# Altium schematic sheet CONNECTOR_IN.SchDoc(CONNECTOR_IN)
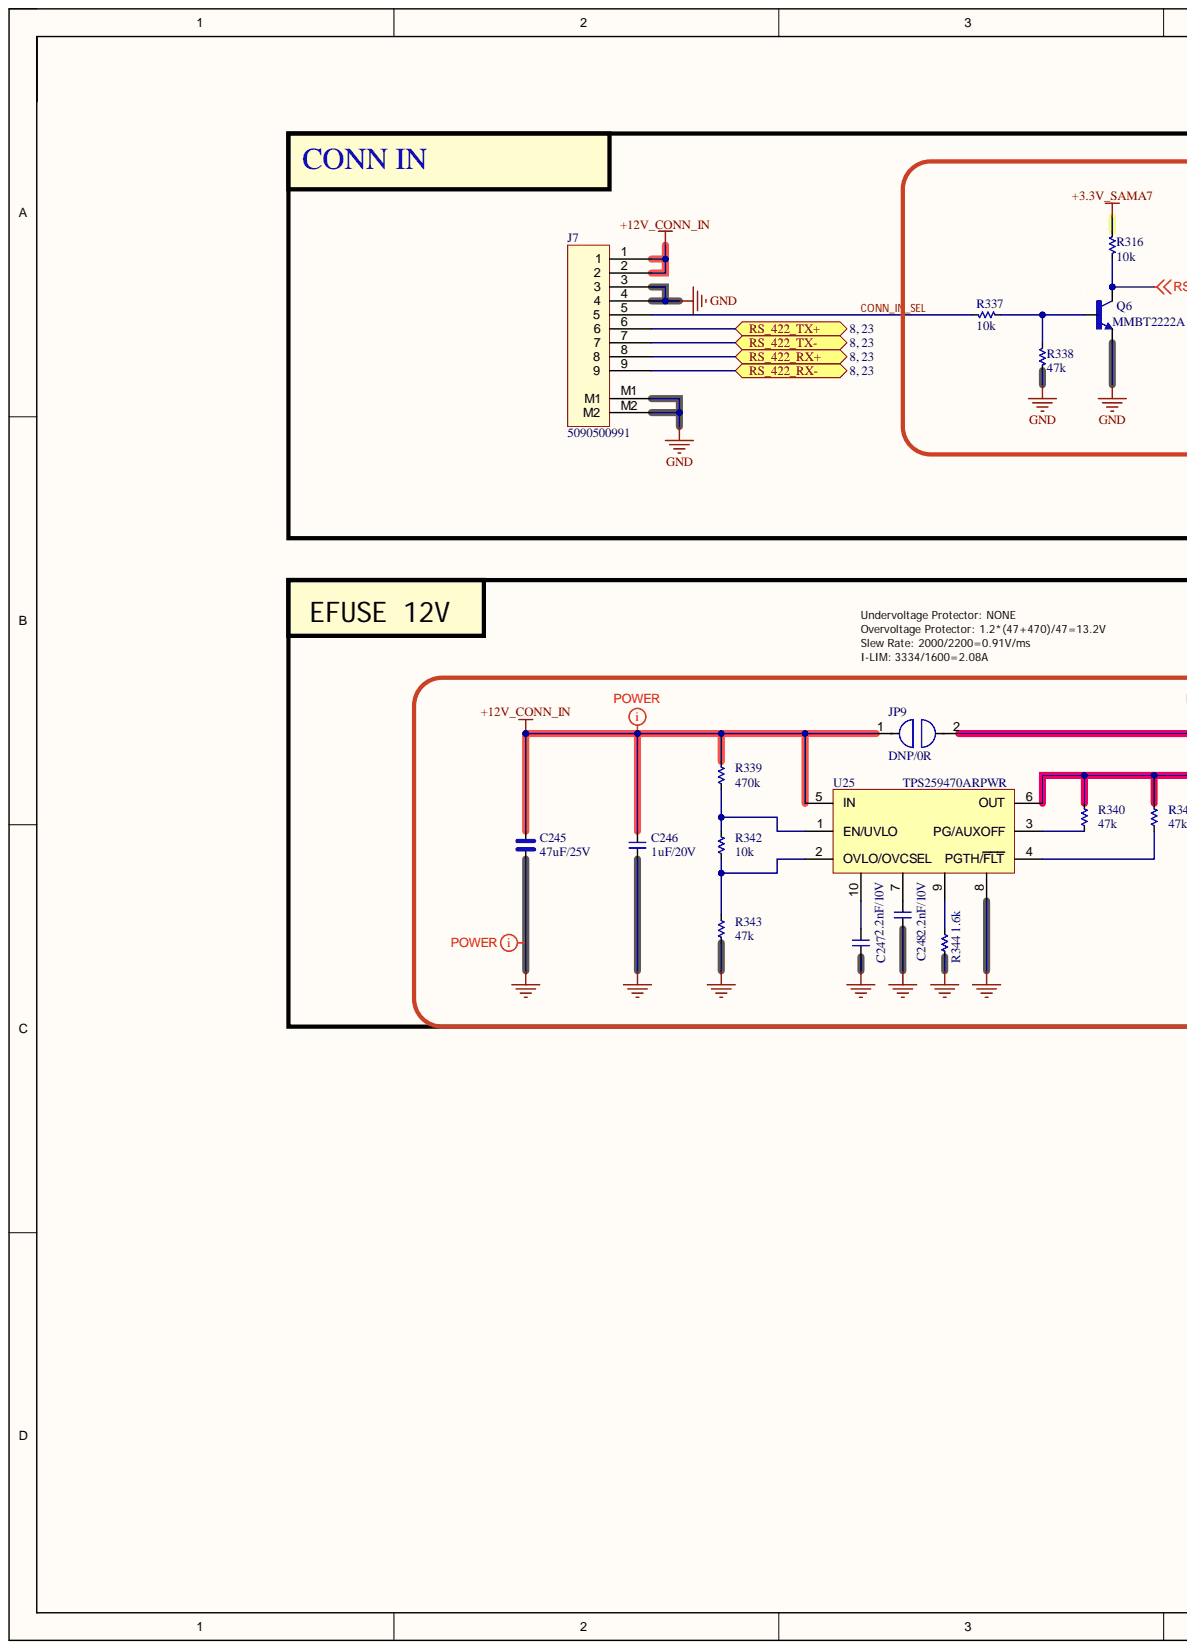
[diagram p0: CONNECTOR_IN.SchDoc, left]
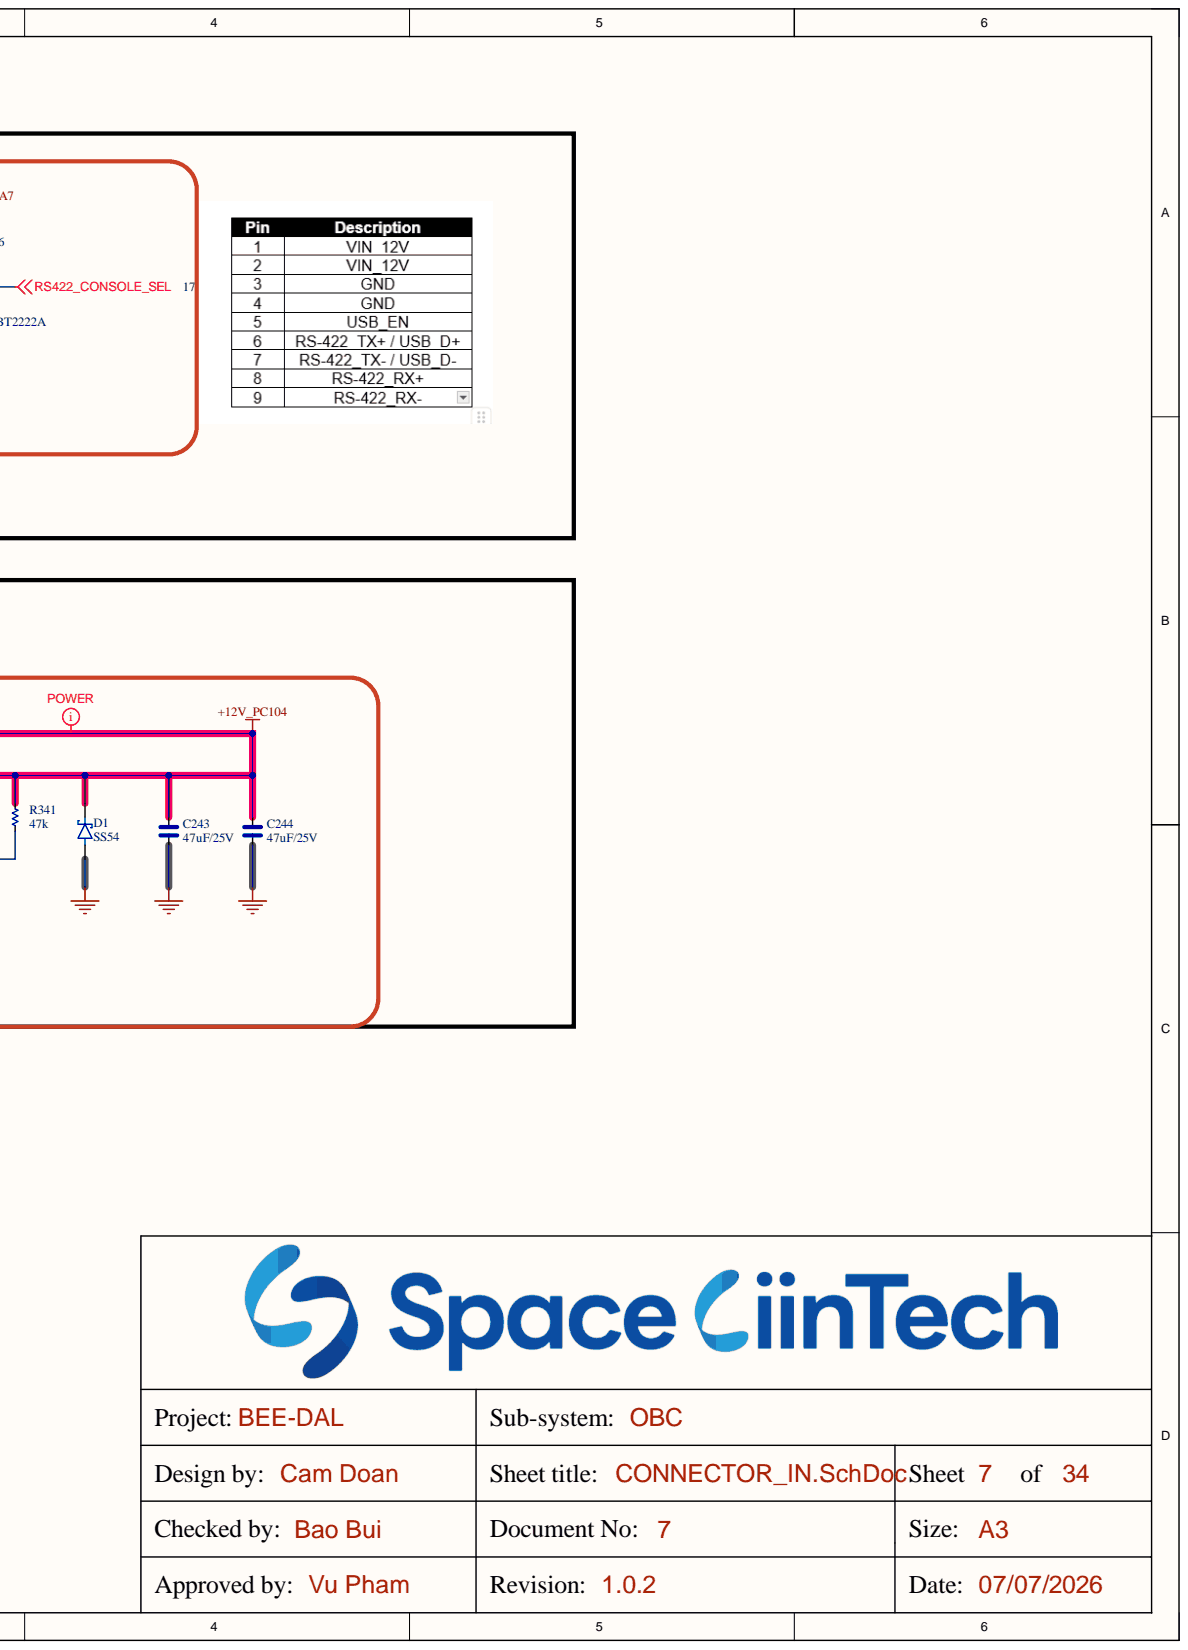
[diagram p1: CONNECTOR_IN.SchDoc, right]

## Parts
C243  47uF/25V  [CAP_C_3225X80]
C244  47uF/25V  [CAP_C_3225X80]
C245  47uF/25V  [CAP_C_3225X80]
C246  1uF/20V  [CAP_C_1005X50_L]
C247  2.2nF/10V  [CAP_C_1005X50_L]
C248  2.2nF/10V  [CAP_C_1005X50_L]
D1  SS54  [DO-214AC_SMA]
J7  5090500991  [MOLEX_5040500991]
JP9  DNP/0R  [JUMPER_1608]
Q6  MMBT2222A  [SOT95P240X120-3N]
R316  10k  [RES_C_1005x30_L]
R337  10k  [RES_C_1005x30_L]
R338  47k  [RES_C_1005x30_L]
R339  470k  [RES_C_1005x30_L]
R340  47k  [RES_C_1005x30_L]
R341  47k  [RES_C_1005x30_L]
R342  10k  [RES_C_1005x30_L]
R343  47k  [RES_C_1005x30_L]
R344  1.6k  [RES_C_1005x30_L]
U25  TPS259470ARPWR  [VQFN-HR-10]

## Ports
RS_422_RX+, RS_422_RX-, RS_422_TX+, RS_422_TX-

## Nets
+3.3V_SAMA7: R316.2
+12V_CONN_IN: C245.2 C246.2 J7.1 J7.2 JP9.1 R339.2 U25.5(IN)
+12V_PC104: C243.2 C244.2 D1.1 JP9.2 R340.2 R341.2 U25.6(OUT)
GND: C243.1 C244.1 C245.1 C246.1 C247.1 C248.1 D1.2 J7.3 J7.4 J7.M1 J7.M2 Q6.2 R338.1 R343.1 R344.1 U25.8
RS422_CONSOLE_SEL: Q6.3 R316.1
CONN_IN_SEL: J7.5 R337.1
NetC247_2: C247.2 U25.10
NetC248_2: C248.2 U25.7
NetJ7_6: J7.6
NetJ7_7: J7.7
NetJ7_8: J7.8
NetJ7_9: J7.9
NetQ6_1: Q6.1 R337.2 R338.2
NetR339_1: R339.1 R342.1 U25.1(EN/UVLO)
NetR340_1: R340.1 U25.3(PG/AUXOFF)
NetR341_1: R341.1 U25.4(PGTH/FLT)
NetR342_2: R342.2 R343.2 U25.2(OVLO/OVCSEL)
NetR344_2: R344.2 U25.9

## Library data of the parts
C243-C245 = _CAP_C_High_2: CAP High Value; Component Kind: Standard; Library Name: LABLIB.SchLib
C246-C248 = _CAP_C_2: CAP; Component Kind: Standard; Library Name: LABLIB.SchLib
D1 = DIODE SCHOTTKY: Component Kind: Standard; Description: DIODE SCHOTTKY; Library Name: LABLIB.SchLib
J7 = Header 9x1_2M: Component Kind: Standard; Library Name: LABLIB.SchLib
JP9 = JUMPER: Component Kind: Standard; Library Name: EXP_V1.SchLib
Q6 = BJT_NPN_BEC: MF: Diotec Semiconductor; Check_prices: https://www.snapeda.com/parts/MMBT2222A/Diotec/view-part/?ref=eda; Component Kind: Standard; MP: MMBT2222A; Package: SOT-23 Diotec; SnapEDA_Link: https://www.snapeda.com/parts/MMBT2222A/Diotec/view-part/?ref=snap; Library Name: LABLIB.SchLib
R316 R337-R344 = _RES_B_2: RES; Component Kind: Standard
  R316 R337 R339-R344: Library Name: LABLIB.SchLib
  R338: Library Name: IFBschelib.SchLib
U25 = TPS259470ARPWR: Component Kind: Standard; Library Name: EXP_HW_V3.SchLib
